# Altium schematic sheet HW_OVERVIEW.SchDoc
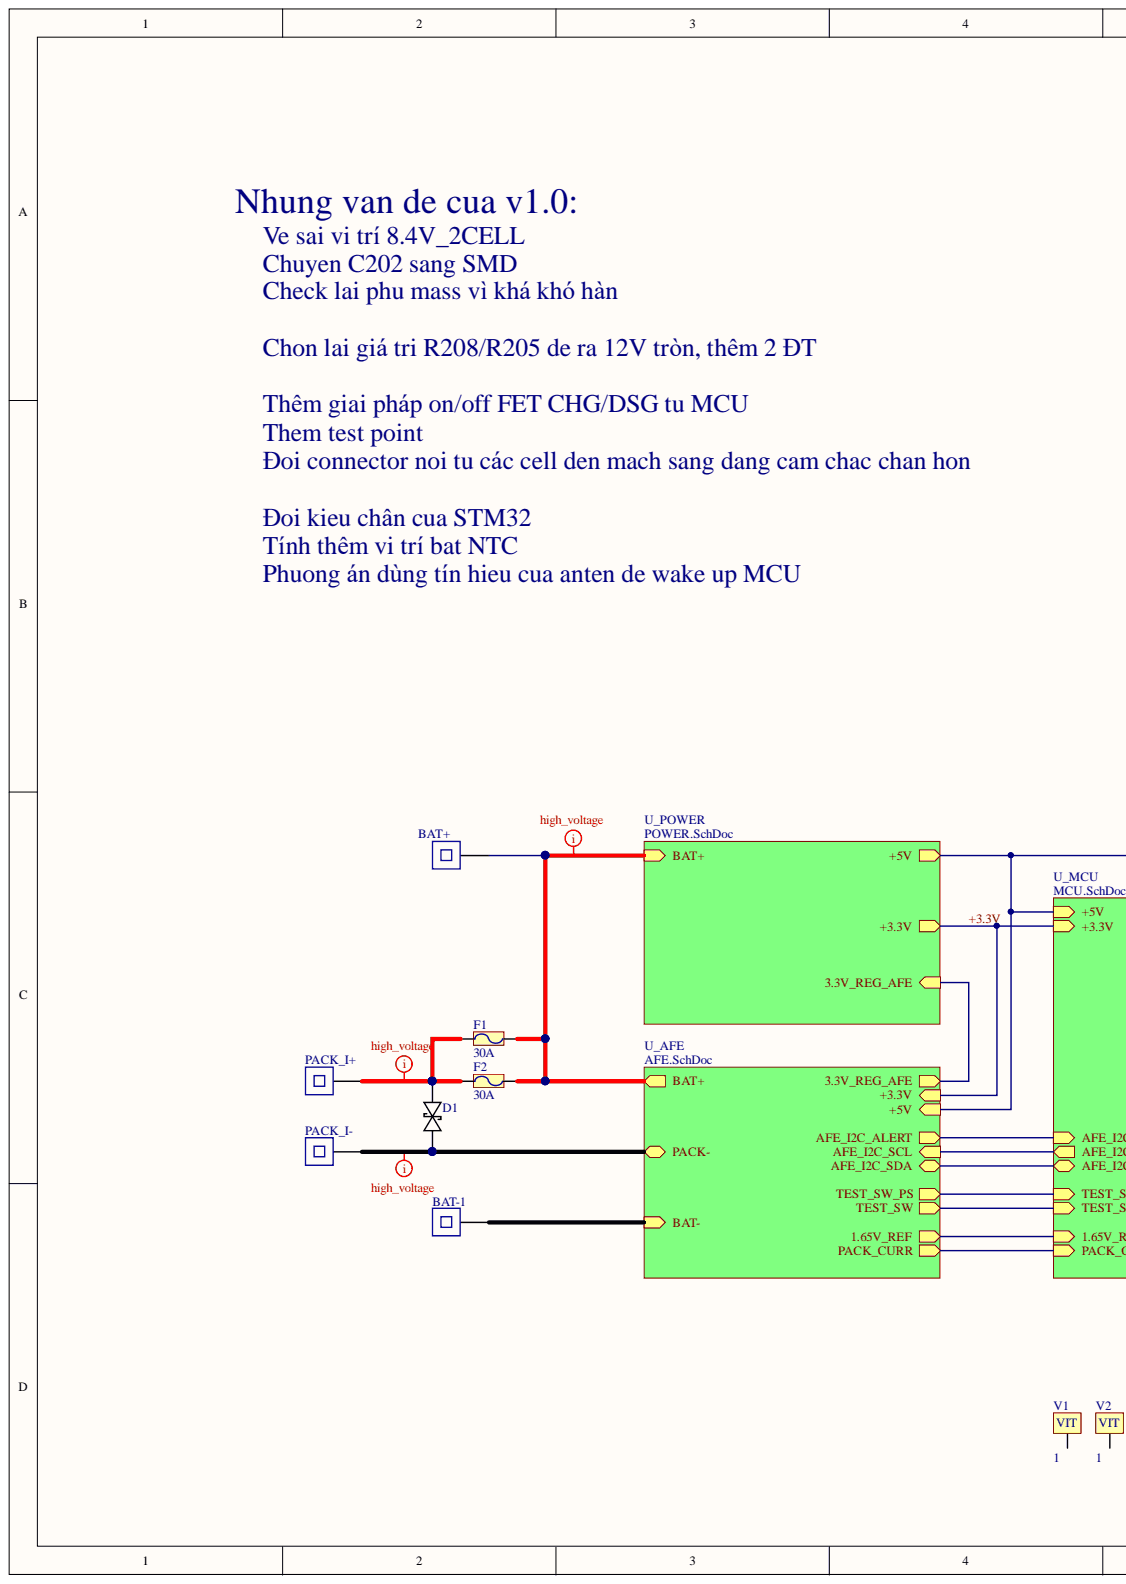
[diagram p0: HW_OVERVIEW.SchDoc, left]
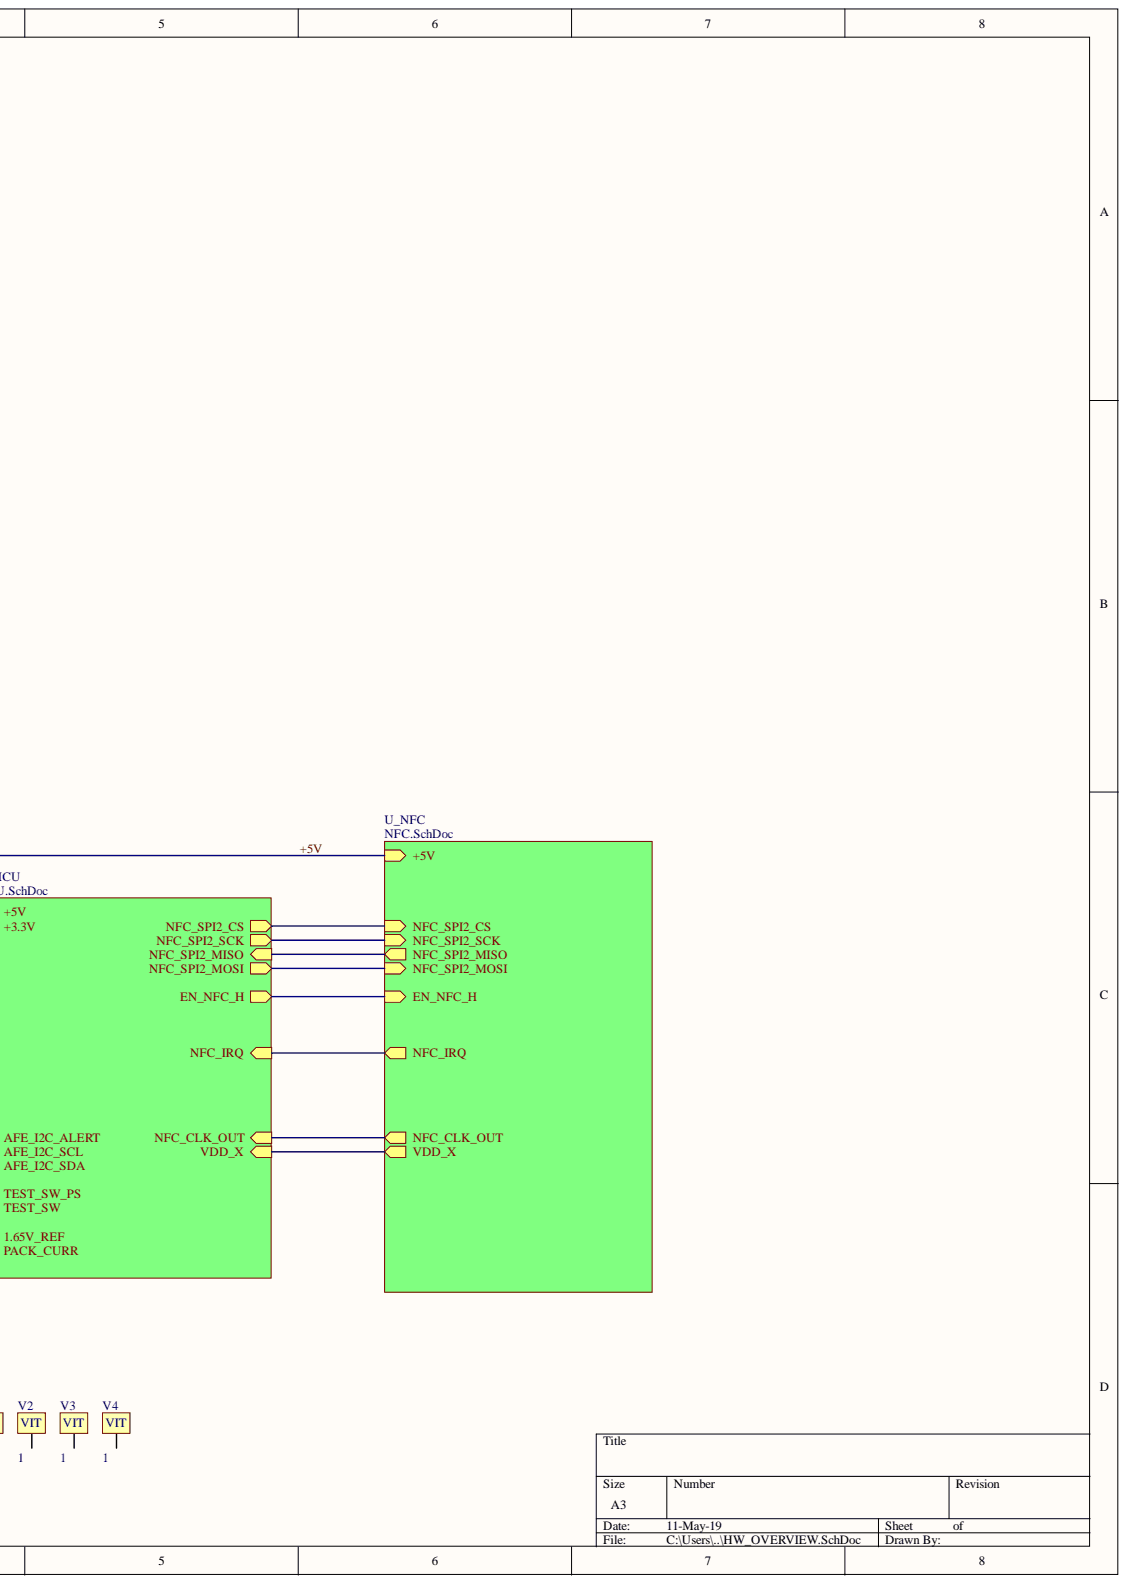
[diagram p1: HW_OVERVIEW.SchDoc, right]

## Parts
BAT+  (no value)  [7798_Terminal]
BAT-1  (no value)  [7798_Terminal]
D1  Diode, TVS  [B72207S0500K211]  (value not drawn)
F1  30A  [FUSE-30A]
F2  30A  [FUSE-30A]
PACK_I+  (no value)  [7798_Terminal]
PACK_I-  (no value)  [7798_Terminal]
V1  1  [VIT_M4]
V2  1  [VIT_M4]
V3  1  [VIT_M4]
V4  1  [VIT_M4]

## Nets
NetBAT+_1: BAT+.1 F1.2 F2.2
NetBAT-1_1: BAT-1.1
NetD1_1: D1.1 F1.1 F2.1 PACK_I+.1
NetD1_2: D1.2 PACK_I-.1
NetV1_VIT1: V1.VIT1
NetV2_VIT1: V2.VIT1
NetV3_VIT1: V3.VIT1
NetV4_VIT1: V4.VIT1
1.65V_REF:
3.3V_REG_AFE:
+3.3V:
+5V:
AFE_I2C_ALERT:
AFE_I2C_SCL:
AFE_I2C_SDA:
EN_NFC_H:
NFC_CLK_OUT:
NFC_IRQ:
NFC_SPI2_CS:
NFC_SPI2_MISO:
NFC_SPI2_MOSI:
NFC_SPI2_SCK:
PACK_CURR:
TEST_SW:
TEST_SW_PS:
VDD_X:

## Library data of the parts
BAT+ BAT-1 PACK_I+ PACK_I- = CON, Header,  2.54, Male, 1P, TH: Header, Unshrouded , 2.54, Male, 1p; Manufacture Part Number: 7798; Manufacturer: Keystone Electronics; Description std: TERM SCREW M4 6 PIN PCB; Value: 7798; Category: Connector; Component Kind: Standard; Digikey Price/1kU: 0.24865; Digikey Price/1unit: 0.57000; Library Name: ACT-Mechanical.SCHLIB; Link: https://www.digikey.com/product-detail/en/keystone-electronics/7798/36-7798-ND/2171014; Package: 7798
D1 = Diode, TVS: Manufacturer: EPCOS (TDK); Description std: VARISTOR 82V 1.2KA DISC 7MM; Value: TVS 82V; Category: DIODE; Component Kind: Standard; Description: Diode, TVS; Digikey Price/1kU: 0.18124; Digikey Price/1unit: 0.44; Library Name: ACT-Active.SCHLIB; Link: https://www.digikey.com/product-detail/en/epcos-tdk/B72207S0500K211/495-6577-1-ND/4931841; Manufacture Part Number: B72207S0500K211; Package: B72207S0500K211
F1 F2 = Fuse: Value: Fuse 30A; Category: FUSE; Component Kind: Standard; Description: Fuse; Package: Fuse 30A; Library Name: syhaunguyen.SchLib
V1-V4 = VIT: Oc Vit; Value: VIT_M4; Category: Screw; Component Kind: Standard; Package: M4 Screw; Library Name: phongnv19.SchLib
